# Altium schematic sheet aux_power_high_vol.SchDoc(aux_power_high_vol)
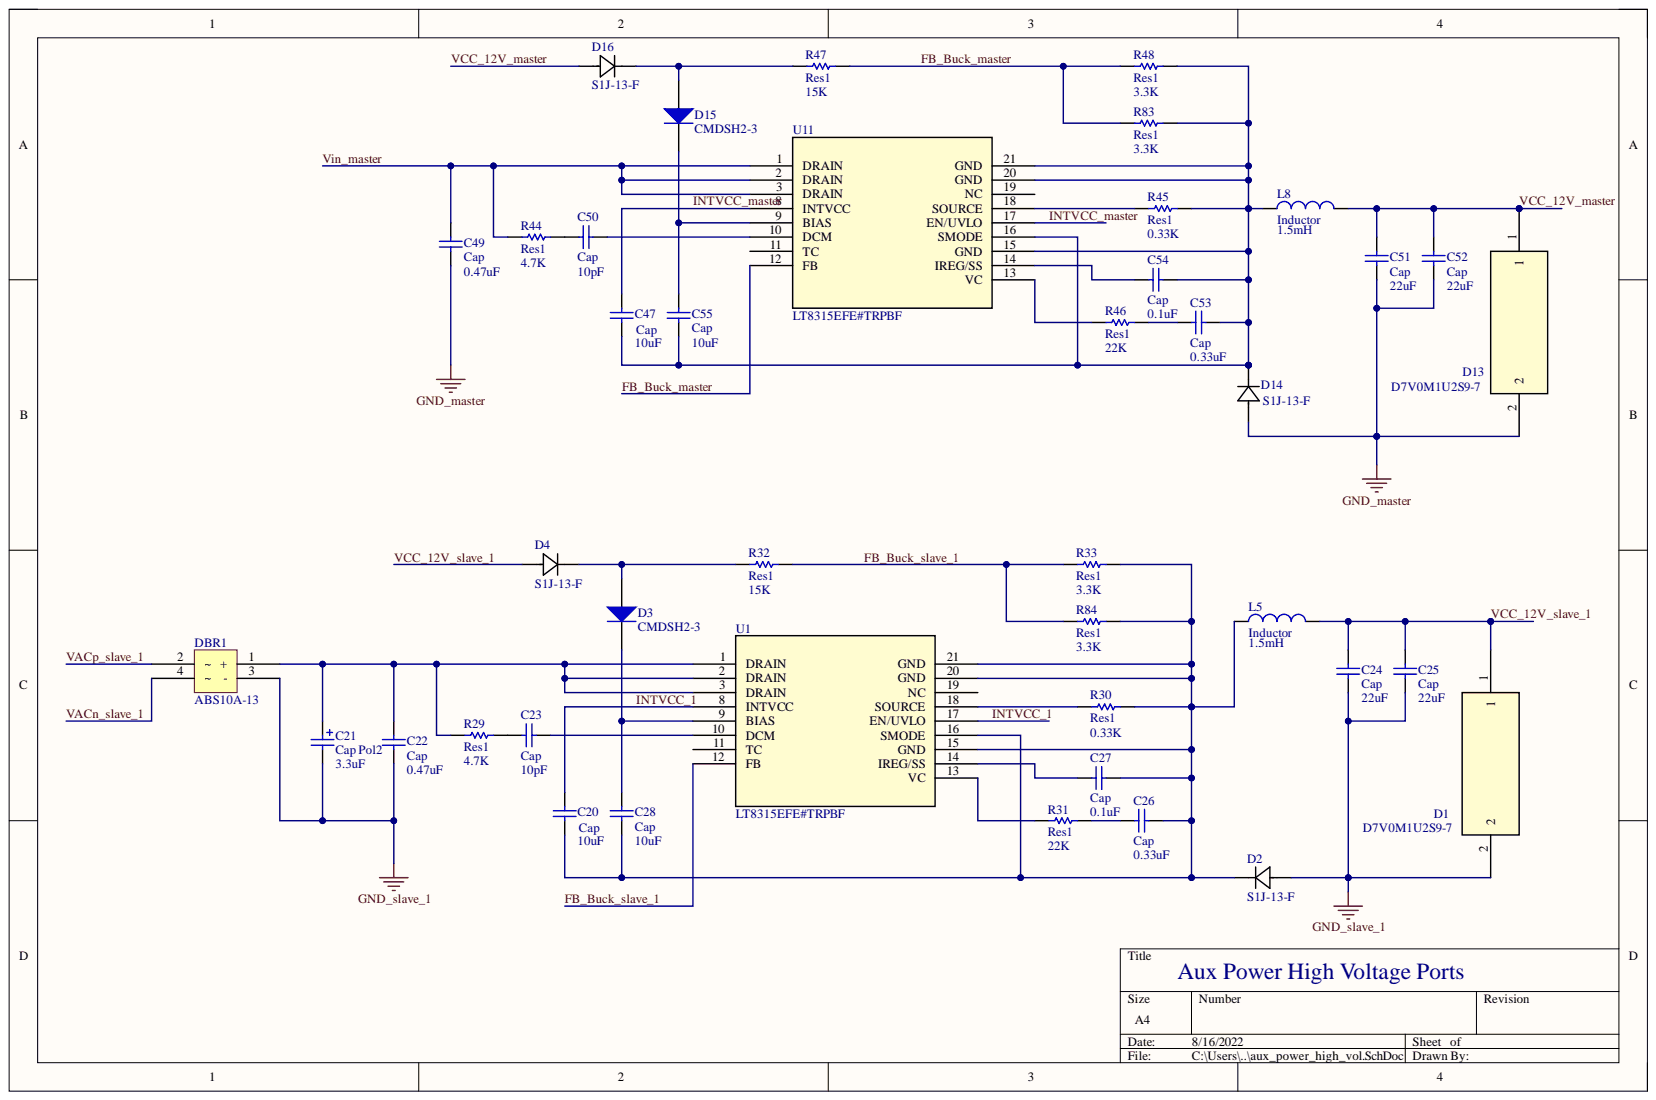
[diagram p0: aux_power_high_vol.SchDoc]

## Parts
C20  Cap  value 10uF  [C0805]
C21  Cap Pol2  value 3.3uF  [Capacitor_P_3.3uF]
C22  Cap  value 0.47uF  [1812]
C23  Cap  value 10pF  [C0805]
C24  Cap  value 22uF  [C0805]
C25  Cap  value 22uF  [C0805]
C26  Cap  value 0.33uF  [C0805]
C27  Cap  value 0.1uF  [C0805]
C28  Cap  value 10uF  [C0805]
C47  Cap  value 10uF  [C0805]
C49  Cap  value 0.47uF  [C0805]
C50  Cap  value 10pF  [C0805]
C51  Cap  value 22uF  [C0805]
C52  Cap  value 22uF  [C0805]
C53  Cap  value 0.33uF  [C0805]
C54  Cap  value 0.1uF  [C0805]
C55  Cap  value 10uF  [C0805]
D1  D7V0M1U2S9-7  [D7V0M1U2S9-7_DIO]
D2  S1J-13-F  [SMA_DIO]
D3  CMDSH2-3  [SOD-323]
D4  S1J-13-F  [SMA_DIO]
D13  D7V0M1U2S9-7  [D7V0M1U2S9-7_DIO]
D14  S1J-13-F  [SMA_DIO]
D15  CMDSH2-3  [SOD-323]
D16  S1J-13-F  [SMA_DIO]
DBR1  ABS10A-13  [ABS10A-13]
L5  Inductor  value 1.5mH  [Inductor_1.5mH]
L8  Inductor  value 1.5mH  [Inductor_1.5mH]
R29  Res1  value 4.7K  [RES0805W]
R30  Res1  value 0.33K  [RES0805W]
R31  Res1  value 22K  [RES0805W]
R32  Res1  value 15K  [RES0805W]
R33  Res1  value 3.3K  [RES0805W]
R44  Res1  value 4.7K  [RES0805W]
R45  Res1  value 0.33K  [RES0805W]
R46  Res1  value 22K  [RES0805W]
R47  Res1  value 15K  [RES0805W]
R48  Res1  value 3.3K  [RES0805W]
R83  Res1  value 3.3K  [RES0805W]
R84  Res1  value 3.3K  [RES0805W]
U1  LT8315EFE#TRPBF  [FE_20BB_ADI]
U11  LT8315EFE#TRPBF  [FE_20BB_ADI]

## Nets
GND_master: C49.1 C51.1 C52.1 D13.2 D14.2
GND_slave_1: C21.2 C22.1 C24.1 C25.1 D1.2 D2.2 DBR1.3(-)
FB_Buck_master: R47.2 R48.1 R83.1 U11.12(FB)
FB_Buck_slave_1: R32.2 R33.1 R84.1 U1.12(FB)
INTVCC_1: C20.2 U1.8(INTVCC) U1.17(EN/UVLO)
INTVCC_master: C47.2 U11.8(INTVCC) U11.17(EN/UVLO)
VACn_slave_1: DBR1.4(~)
VACp_slave_1: DBR1.2(~)
VCC_12V_master: C51.2 C52.2 D13.1 D16.2 L8.2
VCC_12V_slave_1: C24.2 C25.2 D1.1 D4.2 L5.2
Vin_master: C49.2 R44.1 U11.1(DRAIN) U11.2(DRAIN) U11.3(DRAIN)
NetC20_1: C20.1 C26.1 C27.1 C28.1 D2.1 L5.1 R30.2 R33.2 R84.2 U1.15(GND) U1.16(SMODE) U1.20(GND) U1.21(GND)
NetC21_1: C21.1 C22.2 DBR1.1(+) R29.1 U1.1(DRAIN) U1.2(DRAIN) U1.3(DRAIN)
NetC23_1: C23.1 U1.10(DCM)
NetC23_2: C23.2 R29.2
NetC26_2: C26.2 R31.2
NetC27_2: C27.2 U1.14(IREG/SS)
NetC28_2: C28.2 D3.2 U1.9(BIAS)
NetC47_1: C47.1 C53.1 C54.1 C55.1 D14.1 L8.1 R45.2 R48.2 R83.2 U11.15(GND) U11.16(SMODE) U11.20(GND) U11.21(GND)
NetC50_1: C50.1 U11.10(DCM)
NetC50_2: C50.2 R44.2
NetC53_2: C53.2 R46.2
NetC54_2: C54.2 U11.14(IREG/SS)
NetC55_2: C55.2 D15.2 U11.9(BIAS)
NetD3_1: D3.1 D4.1 R32.1
NetD15_1: D15.1 D16.1 R47.1
NetR30_1: R30.1 U1.18(SOURCE)
NetR31_1: R31.1 U1.13(VC)
NetR45_1: R45.1 U11.18(SOURCE)
NetR46_1: R46.1 U11.13(VC)

Not connected: U1 11(TC) 19(NC); U11 11(TC) 19(NC)

## Library data of the parts
C20 C22-C26 C28 C47 C49-C52 C55 = Cap: Capacitor; Component Kind: Standard; LatestRevisionDate: 17-Jul-2002; LatestRevisionNote: Re-released for DXP Platform.; Library Name: Miscellaneous Devices.IntLib; PackageDescription: Radial Cap, Thru-Hole; 2 Leads; 0.3 in Pin Spacing; PackageReference: RAD-0.3; Published: 8-Jun-2000; Signal Integrity: Cap; Simulation: CAP; Publisher: Altium Limited
C21 = Cap Pol2: Polarized Capacitor (Axial); Component Kind: Standard; LatestRevisionDate: 17-Jul-2002; LatestRevisionNote: Re-released for DXP Platform.; Library Name: Miscellaneous Devices.IntLib; PackageDescription: Polarized Capacitor; 2 Leads; PackageReference: POLAR0.8; Published: 8-Jun-2000; Signal Integrity: Cap Pol; Simulation: CAP; Publisher: Altium Limited
C27 C54 = Cap: Capacitor; Value: 0.1uF; Component Kind: Standard; LatestRevisionDate: 17-Jul-2002; LatestRevisionNote: Re-released for DXP Platform.; Library Name: Miscellaneous Devices.IntLib; PackageDescription: Radial Cap, Thru-Hole; 2 Leads; 0.3 in Pin Spacing; PackageReference: RAD-0.3; Published: 8-Jun-2000; Signal Integrity: Cap; Simulation: CAP; Publisher: Altium Limited
C53 = Cap: Capacitor; Value: 0.33uF; Component Kind: Standard; LatestRevisionDate: 17-Jul-2002; LatestRevisionNote: Re-released for DXP Platform.; Library Name: Miscellaneous Devices.IntLib; PackageDescription: Radial Cap, Thru-Hole; 2 Leads; 0.3 in Pin Spacing; PackageReference: RAD-0.3; Published: 8-Jun-2000; Signal Integrity: Cap; Simulation: CAP; Publisher: Altium Limited
D1 D13 = D7V0M1U2S9-7: 13V; Mfr_Name: Diodes Inc; Type: DEV; Component Kind: Standard; Digi-Key_Part_Number_1: 31-D7V0M1U2S9-7TR-ND; Digi-Key_Part_Number_2: 31-D7V0M1U2S9-7CT-ND; Digi-Key_Part_Number_3: 31-D7V0M1U2S9-7DKR-ND; Library Name: D7V0M1U2S9-7.SchLib; Manufacturer_Part_Number: D7V0M1U2S9-7; RefDes: RefDes; Copyright: Copyright (C) 2022 Ultra Librarian. All rights reserved.
D2 D4 D14 D16 = S1J-13-F: No Description Available; Mfr_Name: Diodes Inc; Type: DEV; Component Kind: Standard; Digi-Key_Part_Number_1: S1J-FDICT-ND; Digi-Key_Part_Number_2: S1J-FDIDKR-ND; Digi-Key_Part_Number_3: S1J-FDITR-ND; Library Name: S1J-13-F.SchLib; Manufacturer_Part_Number: S1J-13-F; RefDes: RefDes; Copyright: Copyright (C) 2022 Ultra Librarian. All rights reserved.
D3 D15 = Diode: Default Diode; Code_JEDEC: DO-214-AB; Component Kind: Standard; LatestRevisionDate: 17-Jul-2002; LatestRevisionNote: Re-released for DXP Platform.; Library Name: Miscellaneous Devices.IntLib; PackageDescription: DO-214-AB/SMC; 2 C-Bend Leads; Body 7.9 x 5.9 mm, inc. leads (LxW); PackageReference: SMC; PackageVersion: Sep-1996; Published: 8-Jun-2000; Signal Integrity: Diode; Simulation: DIODE; Publisher: Altium Limited
L5 L8 = Inductor: Code_IEC: 1005; Code_JEITA: 0402; Component Kind: Standard; Description: Inductor; LatestRevisionDate: 29-May-2009; LatestRevisionNote: IPC-7351 Footprint Added.; Library Name: Miscellaneous Devices.IntLib; PackageDescription: Chip Inductor; PackageReference: 0402-A; Published: 8-Jun-2000; Signal Integrity: Inductor; Simulation: INDUCTOR; Publisher: Altium Limited
R29 R31 R32 R44 R46 R47 R83 R84 = Res1: Resistor; Component Kind: Standard; LatestRevisionDate: 17-Jul-2002; LatestRevisionNote: Re-released for DXP Platform.; Library Name: Miscellaneous Devices.IntLib; PackageDescription: Axial Device, Thru-Hole; 2 Leads; 0.3 in Pin Spacing; PackageReference: AXIAL-0.3; Published: 8-Jun-2000; Signal Integrity: Res; Simulation: RESISTOR; Publisher: Altium Limited
R30 R45 = Res1: Resistor; Value: 0.33K; Component Kind: Standard; LatestRevisionDate: 17-Jul-2002; LatestRevisionNote: Re-released for DXP Platform.; Library Name: Miscellaneous Devices.IntLib; PackageDescription: Axial Device, Thru-Hole; 2 Leads; 0.3 in Pin Spacing; PackageReference: AXIAL-0.3; Published: 8-Jun-2000; Signal Integrity: Res; Simulation: RESISTOR; Publisher: Altium Limited
R33 R48 = Res1: Resistor; Value: 3.3K; Component Kind: Standard; LatestRevisionDate: 17-Jul-2002; LatestRevisionNote: Re-released for DXP Platform.; Library Name: Miscellaneous Devices.IntLib; PackageDescription: Axial Device, Thru-Hole; 2 Leads; 0.3 in Pin Spacing; PackageReference: AXIAL-0.3; Published: 8-Jun-2000; Signal Integrity: Res; Simulation: RESISTOR; Publisher: Altium Limited
U1 U11 = LT8315EFE#TRPBF: No Description Available; Mfr_Name: Analog Devices Inc; Component Kind: Standard; Digi-Key_Part_Number_1: LT8315EFE#TRPBF-ND; Manufacturer_Part_Number: LT8315EFE#TRPBF; RefDes: RefDes; Type: DEV; Copyright: Copyright (C) 2022 Ultra Librarian. All rights reserved.; Library Name: LT8315EFE&hash_TRPBF.SchLib
DBR1 = ABS10A-13: Component Kind: Standard; Library Name: ABS10A-13.SchLib
